# Altium schematic sheet Master.SchDoc(Master)
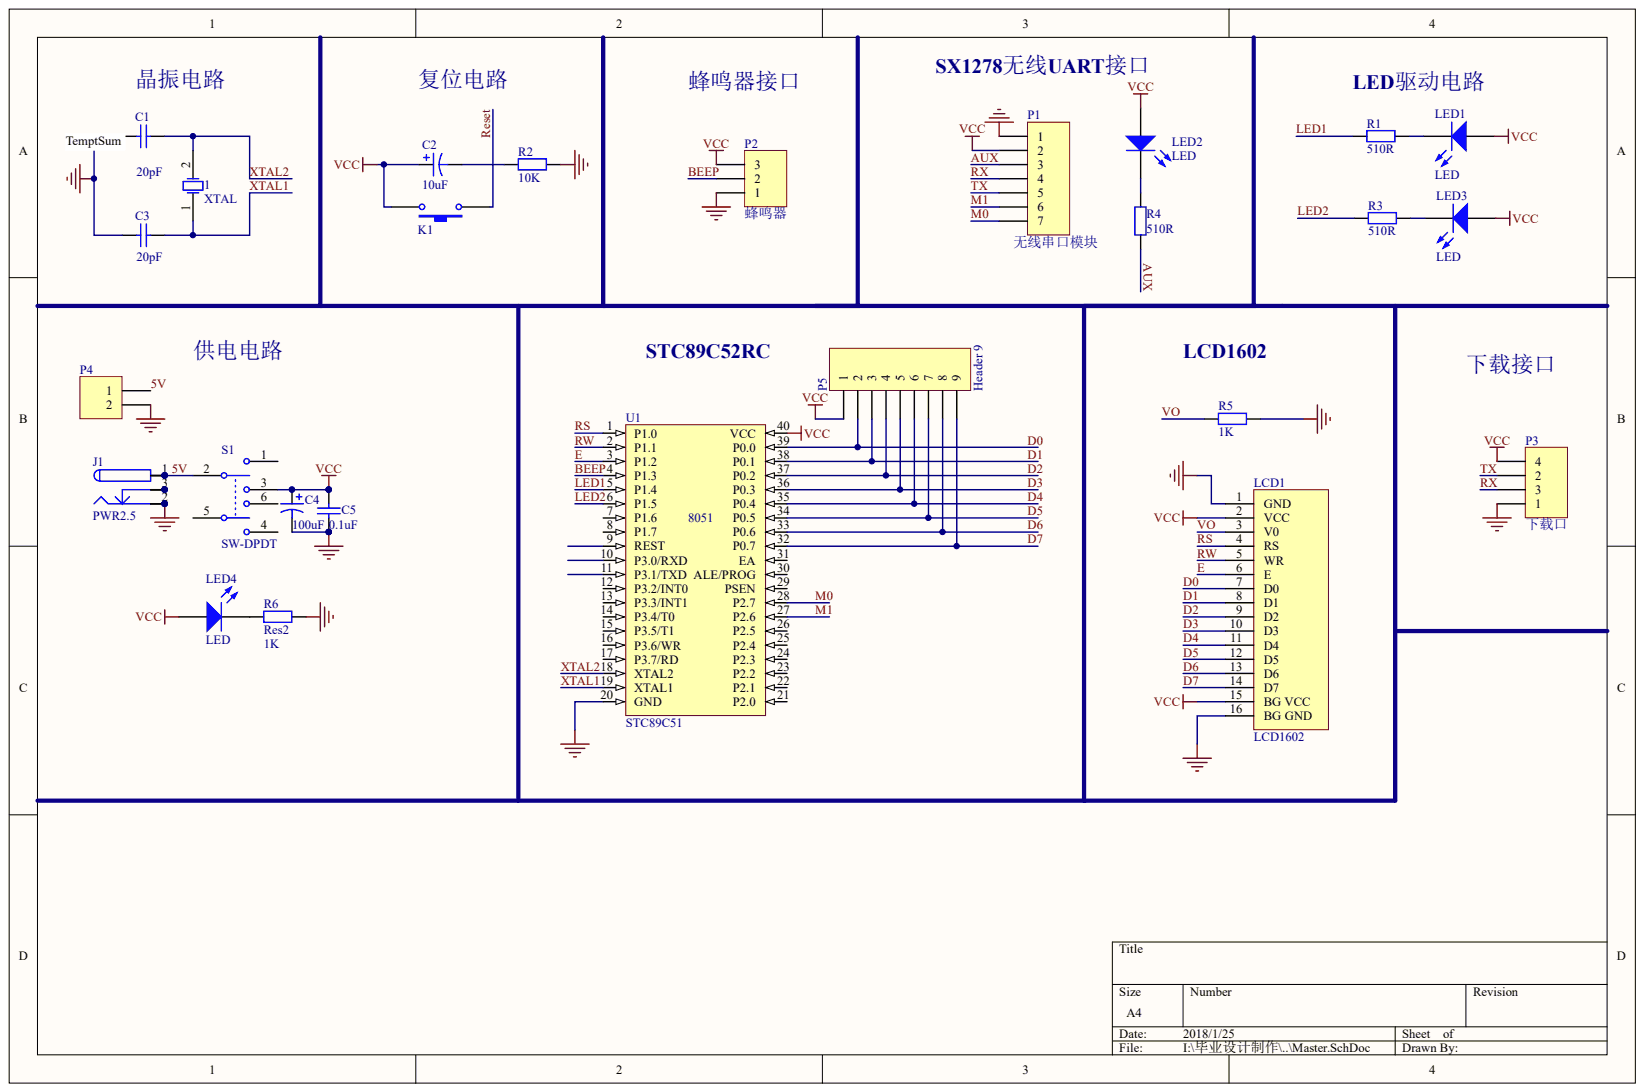
[diagram p0: Master.SchDoc]

## Parts
1  XTAL
C1  value 20pF  (hidden comment: Cap)
C2  value 10uF  (hidden comment: Cap Pol1)
C3  value 20pF  (hidden comment: Cap)
C4  value 100uF  (hidden comment: Cap Pol1)
C5  value 0.1uF  (hidden comment: Cap)
J1  PWR2.5
K1  四脚按键  (value not drawn)
LCD1  LCD1602
LED1  LED
LED2  LED
LED3  LED
LED4  LED
P1  无线串口模块
P2  蜂鸣器
P3  下载口
P4  (no value)
P5  Header 9
R1  value 510R  (hidden comment: Res2)
R2  value 10K  (hidden comment: Res2)
R3  value 510R  (hidden comment: Res2)
R4  value 510R  (hidden comment: Res2)
R5  value 1K  (hidden comment: Res2)
R6  Res2  value 1K
S1  SW-DPDT
U1  STC89C51

## Nets
GND: C1.2 C3.2 C4.2 C5.1 J1.2 J1.2A J1.2B LCD1.1(GND) LCD1.16(BG_GND) P1.1 P2.1 P3.1 P4.2 R2.1 R5.2 R6.2 U1.20(GND)
VCC: C2.1 C4.1 C5.2 K1.1 LCD1.2(VCC) LCD1.15(BG_VCC) LED1.1 LED2.1 LED3.1 LED4.1 P1.2 P2.3 P3.4 P5.1 S1.3 U1.40(VCC)
5V: J1.1 J1.1A J1.1B P4.1 S1.2
AUX: P1.3 R4.1
BEEP: P2.2 U1.4(P1.3)
D0: LCD1.7(D0) P5.2 U1.39(P0.0)
D1: LCD1.8(D1) P5.3 U1.38(P0.1)
D2: LCD1.9(D2) P5.4 U1.37(P0.2)
D3: LCD1.10(D3) P5.5 U1.36(P0.3)
D4: LCD1.11(D4) P5.6 U1.35(P0.4)
D5: LCD1.12(D5) P5.7 U1.34(P0.5)
D6: LCD1.13(D6) P5.8 U1.33(P0.6)
D7: LCD1.14(D7) P5.9 U1.32(P0.7)
E: LCD1.6(E) U1.3(P1.2)
LED1: R1.1 U1.5(P1.4)
LED2: R3.1 U1.6(P1.5)
M0: P1.7 U1.28(P2.7)
M1: P1.6 U1.27(P2.6)
RESET: K1.2
RS: LCD1.4(RS) U1.1(P1.0)
RW: LCD1.5(WR) U1.2(P1.1)
RX: P1.4 P3.3
TX: P1.5 P3.2
VO: LCD1.3(V0) R5.1
XTAL1: 1.1 C3.1 U1.19(XTAL1)
XTAL2: 1.2 C1.1 U1.18(XTAL2)
NetC2_2: C2.2 R2.2
NetJ1_3: J1.3 J1.3A J1.3B
NetLED1_2: LED1.2 R1.2
NetLED2_2: LED2.2 R4.2
NetLED3_2: LED3.2 R3.2
NetLED4_2: LED4.2 R6.1

Not connected: S1 1 4 5 6; U1 7(P1.6) 8(P1.7) 9(REST) 10(P3.0/RXD) 11(P3.1/TXD) 12(P3.2/INT0) 13(P3.3/INT1) 14(P3.4/T0) 15(P3.5/T1) 16(P3.6/WR) 17(P3.7/RD) 21(P2.0) 22(P2.1) 23(P2.2) 24(P2.3) 25(P2.4) 26(P2.5) 29(PSEN) 30(ALE/PROG) 31(EA)

## Library data of the parts
1 C1-C5 J1 K1 LCD1 LED1-LED4 P1-P5 R1-R6 S1 U1 = (no symbol name)
  1: Published: 8-Jun-2000; LatestRevisionDate: 17-Jul-2002; LatestRevisionNote: Re-released for DXP Platform.; PackageReference: R38; PackageDescription: Crystal, Thru-Hole; 2 Leads; Body 3.1 x 8.2 mm (Dia.xH)
  C1 C3 C5: Published: 8-Jun-2000; LatestRevisionDate: 17-Jul-2002; LatestRevisionNote: Re-released for DXP Platform.; PackageReference: RAD-0.3; PackageDescription: Radial Cap, Thru-Hole; 2 Leads; 0.3 in Pin Spacing
  C2 C4: Published: 8-Jun-2000; LatestRevisionDate: 17-Jul-2002; LatestRevisionNote: Re-released for DXP Platform.; PackageReference: RB7.6-15; PackageDescription: Polarized Capacitor (Radial); 2 Leads
  J1: Published: 17-Dec-2003; DatasheetDocument: 09-May-2002; ComponentLink1Description: Manufacturer Link; ComponentLink1URL: http://domino.kycon.com/internet/Products.html
  P1-P5: LatestRevisionDate: 17-Jul-2002; LatestRevisionNote: Re-released for DXP Platform.
  R1-R6: Published: 8-Jun-2000; LatestRevisionDate: 17-Jul-2002; LatestRevisionNote: Re-released for DXP Platform.; PackageReference: AXIAL-0.4; PackageDescription: Axial Device, Thru-Hole; 2 Leads; 0.4 in Pin Spacing
  S1: Published: 24-Mar-1999; LatestRevisionDate: 17-Jul-2002; LatestRevisionNote: Re-released for DXP Platform.; PackageReference: DPDT-6
  1 C1-C5 J1 P1-P5 R1-R6 S1: Publisher: Altium Limited
